# Altium schematic sheet Braking_Sensor.SchDoc(Braking_Sensor)
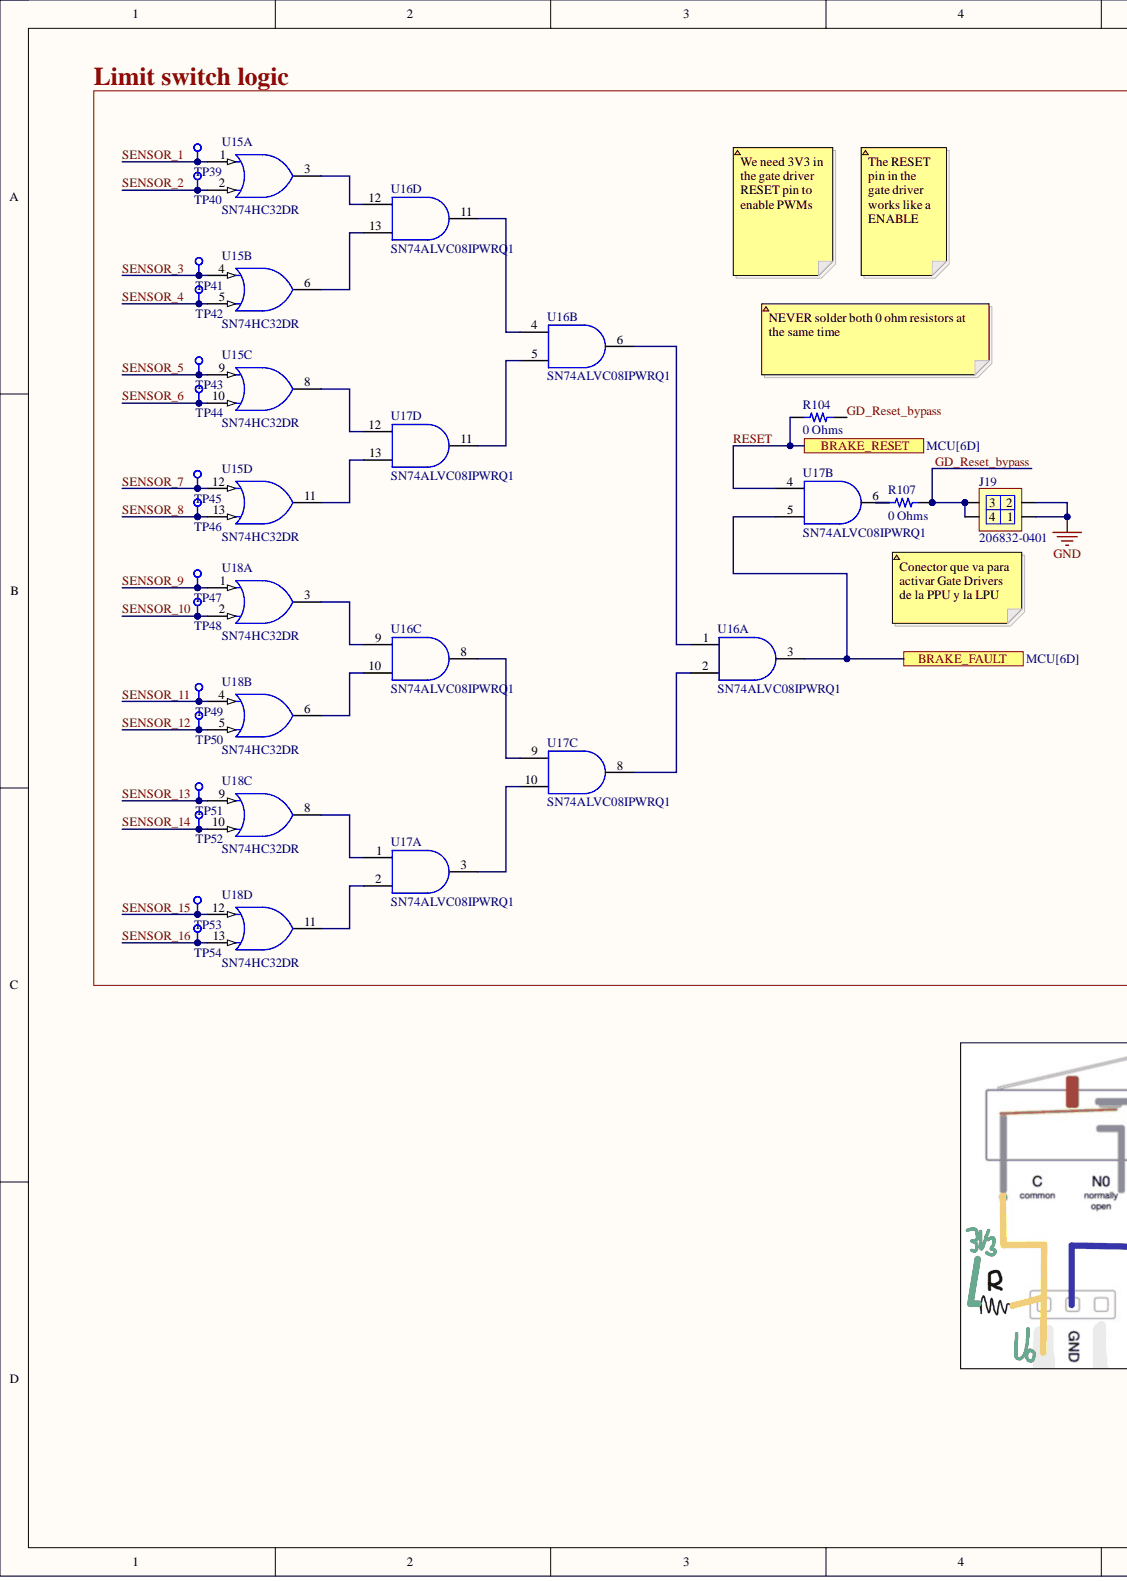
[diagram p0: Braking_Sensor.SchDoc, left]
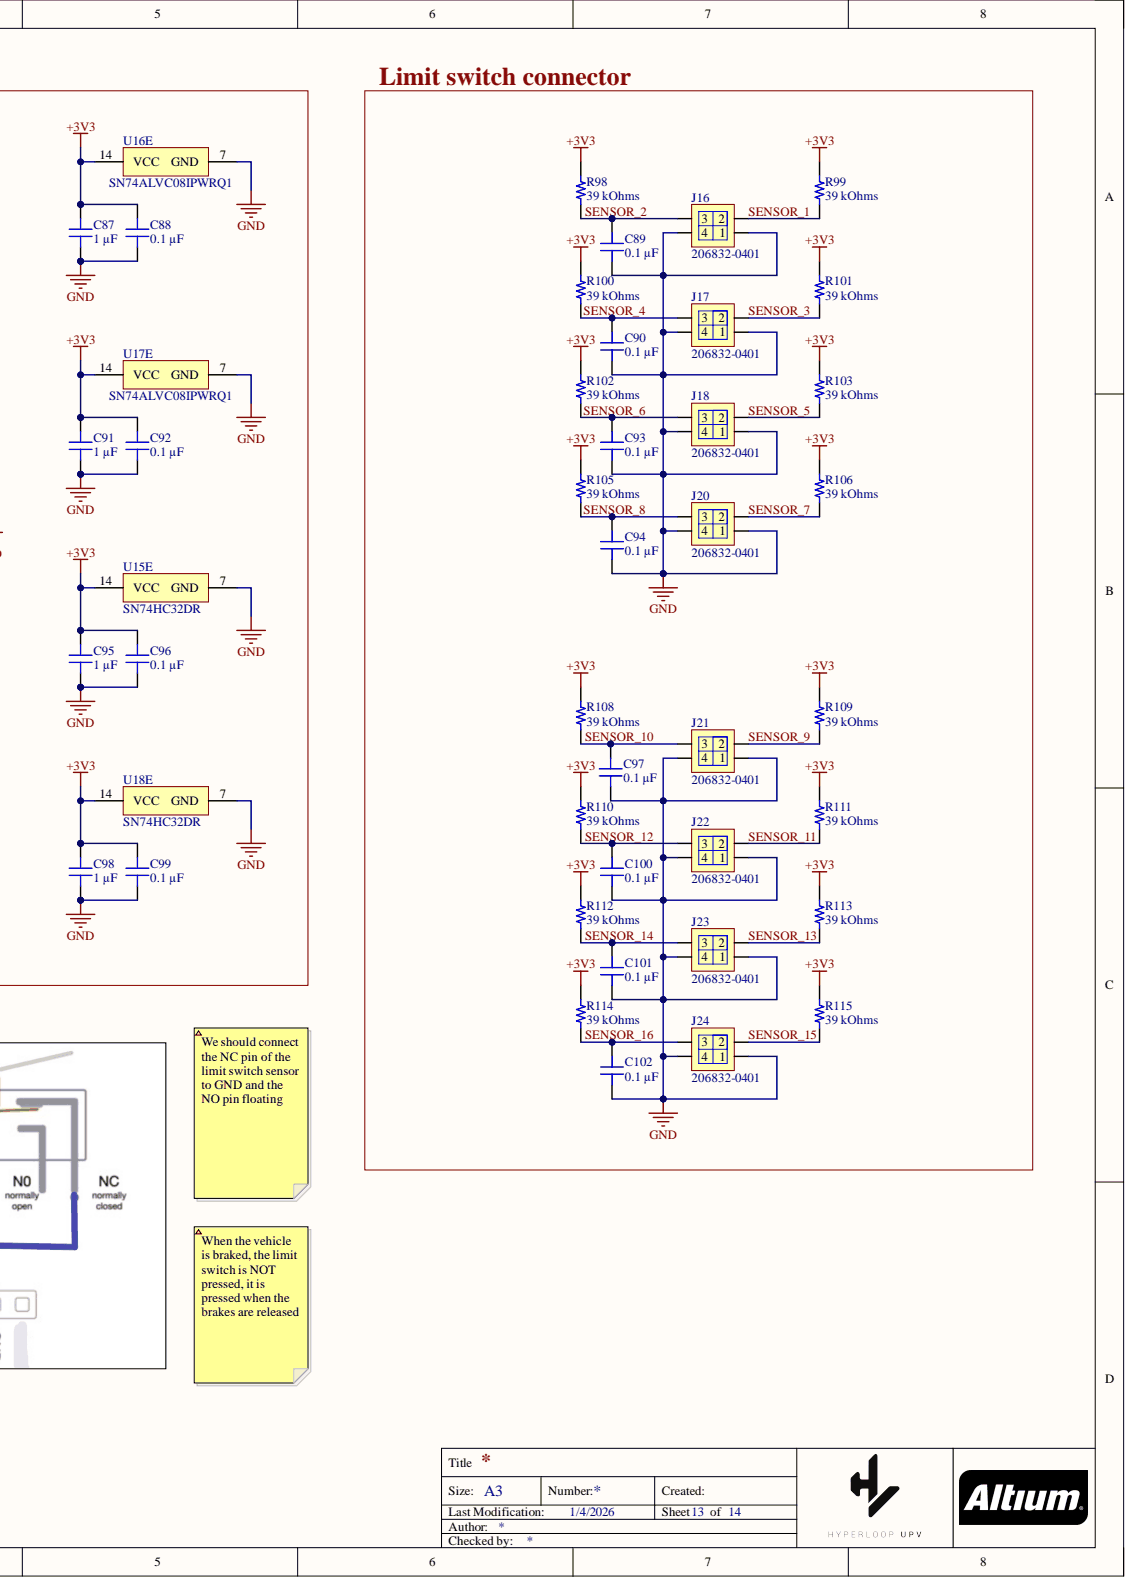
[diagram p1: Braking_Sensor.SchDoc, right]

## Parts
C87  1 µF
C88  0.1 µF
C89  0.1 µF
C90  0.1 µF
C91  1 µF
C92  0.1 µF
C93  0.1 µF
C94  0.1 µF
C95  1 µF
C96  0.1 µF
C97  0.1 µF
C98  1 µF
C99  0.1 µF
C100  0.1 µF
C101  0.1 µF
C102  0.1 µF
J16  206832-0401
J17  206832-0401
J18  206832-0401
J19  206832-0401
J20  206832-0401
J21  206832-0401
J22  206832-0401
J23  206832-0401
J24  206832-0401
R98  39 kOhms
R99  39 kOhms
R100  39 kOhms
R101  39 kOhms
R102  39 kOhms
R103  39 kOhms
R104  0 Ohms
R105  39 kOhms
R106  39 kOhms
R107  0 Ohms
R108  39 kOhms
R109  39 kOhms
R110  39 kOhms
R111  39 kOhms
R112  39 kOhms
R113  39 kOhms
R114  39 kOhms
R115  39 kOhms
TP39  Test point 1.2mm  (value not drawn)
TP40  Test point 1.2mm  (value not drawn)
TP41  Test point 1.2mm  (value not drawn)
TP42  Test point 1.2mm  (value not drawn)
TP43  Test point 1.2mm  (value not drawn)
TP44  Test point 1.2mm  (value not drawn)
TP45  Test point 1.2mm  (value not drawn)
TP46  Test point 1.2mm  (value not drawn)
TP47  Test point 1.2mm  (value not drawn)
TP48  Test point 1.2mm  (value not drawn)
TP49  Test point 1.2mm  (value not drawn)
TP50  Test point 1.2mm  (value not drawn)
TP51  Test point 1.2mm  (value not drawn)
TP52  Test point 1.2mm  (value not drawn)
TP53  Test point 1.2mm  (value not drawn)
TP54  Test point 1.2mm  (value not drawn)
U15  SN74HC32DR  (parts A,B,C,D,E)
U16  SN74ALVC08IPWRQ1  (parts A,B,C,D,E)
U17  SN74ALVC08IPWRQ1  (parts A,B,C,D,E)
U18  SN74HC32DR  (parts A,B,C,D,E)

## Ports
BRAKE_FAULT, BRAKE_RESET

## Nets
+3V3: C87.2 C88.2 C91.2 C92.2 C95.2 C96.2 C98.2 C99.2 R98.2 R99.2 R100.2 R101.2 R102.2 R103.2 R105.2 R106.2 R108.2 R109.2 R110.2 R111.2 R112.2 R113.2 R114.2 R115.2 U15.14(VCC) U16.14(VCC) U17.14(VCC) U18.14(VCC)
GND: C87.1 C88.1 C89.1 C90.1 C91.1 C92.1 C93.1 C94.1 C95.1 C96.1 C97.1 C98.1 C99.1 C100.1 C101.1 C102.1 J16.1 J16.4 J17.1 J17.4 J18.1 J18.4 J19.1 J19.2 J20.1 J20.4 J21.1 J21.4 J22.1 J22.4 J23.1 J23.4 J24.1 J24.4 U15.7(GND) U16.7(GND) U17.7(GND) U18.7(GND)
BRAKE_FAULT: U16.3 U17.5 ; port BRAKE_FAULT
GD_Reset_bypass: J19.3 J19.4 R104.2 R107.2
RESET: R104.1 U17.4 ; port BRAKE_RESET
SENSOR_1: J16.2 R99.1 TP39.1 U15.1
SENSOR_2: C89.2 J16.3 R98.1 TP40.1 U15.2
SENSOR_3: J17.2 R101.1 TP41.1 U15.4
SENSOR_4: C90.2 J17.3 R100.1 TP42.1 U15.5
SENSOR_5: J18.2 R103.1 TP43.1 U15.9
SENSOR_6: C93.2 J18.3 R102.1 TP44.1 U15.10
SENSOR_7: J20.2 R106.1 TP45.1 U15.12
SENSOR_8: C94.2 J20.3 R105.1 TP46.1 U15.13
SENSOR_9: J21.2 R109.1 TP47.1 U18.1
SENSOR_10: C97.2 J21.3 R108.1 TP48.1 U18.2
SENSOR_11: J22.2 R111.1 TP49.1 U18.4
SENSOR_12: C100.2 J22.3 R110.1 TP50.1 U18.5
SENSOR_13: J23.2 R113.1 TP51.1 U18.9
SENSOR_14: C101.2 J23.3 R112.1 TP52.1 U18.10
SENSOR_15: J24.2 R115.1 TP53.1 U18.12
SENSOR_16: C102.2 J24.3 R114.1 TP54.1 U18.13
NetR107_1: R107.1 U17.6
NetU15_3: U15.3 U16.12
NetU15_6: U15.6 U16.13
NetU15_8: U15.8 U17.12
NetU15_11: U15.11 U17.13
NetU16_1: U16.1 U16.6
NetU16_2: U16.2 U17.8
NetU16_4: U16.4 U16.11
NetU16_5: U16.5 U17.11
NetU16_8: U16.8 U17.9
NetU16_9: U16.9 U18.3
NetU16_10: U16.10 U18.6
NetU17_1: U17.1 U18.8
NetU17_2: U17.2 U18.11
NetU17_3: U17.3 U17.10

## Library data of the parts
C87 C91 C95 C98 = C1206C105K5RACAUTO: CAP CER 1UF 50V X7R 1206; manufacturer: KEMET; Database Table Name: Capacitors - Ceramic - 1206; Tolerance: ±10%; Applications: Automotive, Bypass, Decoupling; Capacitance: 1 µF; Component Kind: Standard; componentlink1description: Datasheet; componentlink1url: https://api.kemet.com/component-edge/download/datasheet/C1206C105K5RACAUTO.pdf; componentlink2description: DigiKey Link; componentlink2url: https://www.digikey.com/en/products/detail/kemet/C1206C105K5RACAUTO/3315003; Device Package: 1206 (3216 Metric); lastupdated: 19/09/2022 13:06:35; Library Name: Celestial Altium Library - altium_library.DbLib; Lifecycle Status: Active; Minimum Order: 1; Mounting Type: Surface Mount, MLCC; Operating Temperature: -55°C ~ 125°C; Package / Case: 1206 (3216 Metric); packaging: TapeAndReel; Part Number: C1206C105K5RACAUTO; Part Status: Active; partid: 19104; price: 0.06; Ratings: AEC-Q200; series: SMD Auto X7R; Size / Dimension: 0.126" L x 0.063" W (3.20mm x 1.60mm); Supplier 1: DigiKey; Supplier Part Number 1: 399-7011-1-ND; Temperature Coefficient: X7R; Thickness (Max): 0.043" (1.10mm); Value: 1 µF; Voltage - Rated: 50V
C88-C90 C92-C94 C96 C97 C99-C102 = CS0603KRX7R7BB104: CAP CER 0.1UF 16V X7R 0603; manufacturer: Yageo; Database Table Name: Capacitors - Ceramic - 0603; Tolerance: ±10%; Applications: Boardflex Sensitive; Capacitance: 0.1 µF; Component Kind: Standard; componentlink1description: Datasheet; componentlink1url: http://www.yageo.com/NewPortal/yageodocoutput?fileName=/pdf/MLCC/UPY-ST_NPOX7R_16V-to-3KV_5.pdf; componentlink2description: DigiKey Link; componentlink2url: https://www.digikey.com/en/products/detail/yageo/CS0603KRX7R7BB104/6097897; Device Package: 0603 (1608 Metric); Features: Soft Termination; lastupdated: 19/09/2022 13:01:20; Library Name: Celestial Altium Library - altium_library.DbLib; Lifecycle Status: Active; Minimum Order: 1; Mounting Type: Surface Mount, MLCC; Operating Temperature: -55°C ~ 125°C; Package / Case: 0603 (1608 Metric); packaging: TapeAndReel; Part Number: CS0603KRX7R7BB104; Part Status: Active; partid: 2286; price: 0.02; series: CS; Size / Dimension: 0.063" L x 0.031" W (1.60mm x 0.80mm); Supplier 1: DigiKey; Supplier Part Number 1: 311-3560-1-ND; Temperature Coefficient: X7R; Thickness (Max): 0.037" (0.95mm); Value: 0.1 µF; Voltage - Rated: 16V
J16-J24 = CMP-006-00301-2: MICRO-FIT Plus Header Assembly Dual Row 4 Position Straight Tin; Connector Type: Micro-Fit+; Gender: Male; Max Current: 12.5 A; Max Voltage: 600V AC (RMS)/DC; Component Kind: Standard; Max Operating Temperature: 105°C; Min Operating Temperature: -40°C; Mounting Technology: THT; Pins: 4; RoHS Compliant: Yes; Library Name: Hyperloop UPV
R98-R103 R105 R106 R108-R115 = ERA-3AEB393V: RES SMD 39K OHM 0.1% 1/10W 0603; Manufacturer: Panasonic Electronic Components; Database Table Name: Resistors - Surface Mount - 0603; Power (Watts): 0.1W, 1/10W; Temperature Coefficient: ±25ppm/°C; Component Kind: Standard; ComponentLink1Description: Datasheet; ComponentLink1URL: https://industrial.panasonic.com/cdbs/www-data/pdf/RDM0000/AOA0000C307.pdf; ComponentLink2Description: DigiKey Link; ComponentLink2URL: https://www.digikey.com/en/products/detail/panasonic-electronic-components/ERA-3AEB393V/1465892; Composition: Thin Film; Device Package: 0603 (1608 Metric); Features: Automotive AEC-Q200; Height - Seated (Max): 0.022" (0.55mm); LastUpdated: 2/9/2024 8:43:34 PM; Library Name: Celestial Altium Library - altium_library.DbLib; Lifecycle Status: Active; Minimum Order: 1; Number of Terminations: 2; Operating Temperature: -55°C ~ 155°C; Package / Case: 0603 (1608 Metric); Packaging: TapeAndReel; Part Number: ERA-3AEB393V; Part Status: Active; PartId: 5552; Price: 0.03; Ratings: AEC-Q200; Resistance: 39 kOhms; Series: ERA-3A; Size / Dimension: 0.063" L x 0.031" W (1.60mm x 0.80mm); Supplier 1: DigiKey; Supplier Device Package: 0603; Supplier Part Number 1: P39KDBCT-ND; Tolerance: ±0.1%; Value: 39 kOhms
R104 R107 = TLRZ1JTTD: RES 0 OHM JUMPER 0603; Manufacturer: KOA Speer Electronics, Inc.; Database Table Name: Resistors - Surface Mount - 0603; Component Kind: Standard; ComponentLink1Description: Datasheet; ComponentLink1URL: http://www.koaspeer.com/catimages/Products/TLRZ/TLRZ.pdf; ComponentLink2Description: DigiKey Link; ComponentLink2URL: https://www.digikey.com/en/products/detail/koa-speer-electronics-inc/TLRZ1JTTD/9845493; Composition: Metal Element; Device Package: 0603 (1608 Metric); Features: Automotive AEC-Q200, Current Sense; Height - Seated (Max): 0.022" (0.55mm); LastUpdated: 09/02/2024 20:43:37; Library Name: Celestial Altium Library - altium_library.DbLib; Lifecycle Status: Active; Minimum Order: 1; Number of Terminations: 2; Operating Temperature: -55°C ~ 170°C; Package / Case: 0603 (1608 Metric); Packaging: TapeAndReel; Part Number: TLRZ1JTTD; Part Status: Active; PartId: 4851; Price: 0.08; Ratings: AEC-Q200; Resistance: 0 Ohms; Series: TLRZ; Size / Dimension: 0.063" L x 0.031" W (1.60mm x 0.80mm); Supplier 1: DigiKey; Supplier Device Package: 0603; Supplier Part Number 1: 2019-TLRZ1JTTDCT-ND; Tolerance: Jumper; Value: 0 Ohms
TP39-TP54 = CMP-006-00250-2: 1.2mm Test point; Component Kind: Standard; Library Name: Hyperloop UPV
U15 U18 = CMP-016-00002-2: 4-ch 2-input 2-V to 6-V 5.2 mA drive strength OR gate 14-SOIC -40 to 85; Max Supply Voltage: 6V; Min Supply Voltage: 2V; Case/Package: SOIC; Component Kind: Standard; Max Operating Temperature: 85°C; Min Operating Temperature: -40°C; Mounting Technology: Surface Mount; Pins: 14; Library Name: Hyperloop UPV
U16 U17 = CMP-00789-2: AND Gate, ALVC/VCX/A Series, 4-Func, 2-Input, CMOS, PDSO14; Load Capacitance (CL): 50pF; Max I(ol): 24mA; Number of Functions: 4; Number of Inputs: 2; Package Body Material: Plastic; Package Equivalence Code: TSSOP14,.25; Power Supplies: 3.3V; Prop. Delay@Nom-Sup: 2.9ns; Propagation Delay (tpd): 5.3ns; Qualification Status: Not Qualified; Schmitt Trigger: No; Supply Voltage-Max (Vsup): 3.6V; Supply Voltage-Min (Vsup): 1.65V; Supply Voltage-Nom (Vsup): 1.8V; Component Kind: Standard; Height - Seated (Max): 1.2mm; JESD-30 Code: R-PDSO-G14; JESD-609 Code: e4; Length: 5mm; Logic IC Type: AND GATE; Max Operating Temperature: 85°C; Min Operating Temperature: -40°C; Moisture Sensitivity Level: 1; Number of Pins: 14; Package Code: TSSOP; Package Shape: Rectangular; Package Style: SMALL OUTLINE, THIN PROFILE, SHRINK PITCHMeter; Packaging: Tape and Reel; Peak Reflow Temperature (Cel): 260°C; Reach SVHC Compliant: Yes; RoHS Compliant: Yes; Surface Mount: Yes; Technology: CMOS; Temperature Grade: INDUSTRIAL; Terminal Finish: Nickel; Terminal Form: Gull Wing; Terminal Pitch: 0.65mm; Terminal Position: DUAL; Width: 4.4mm; Library Name: Hyperloop UPV
